# Altium schematic sheet MCU_EW.SchDoc(MCU_EW)
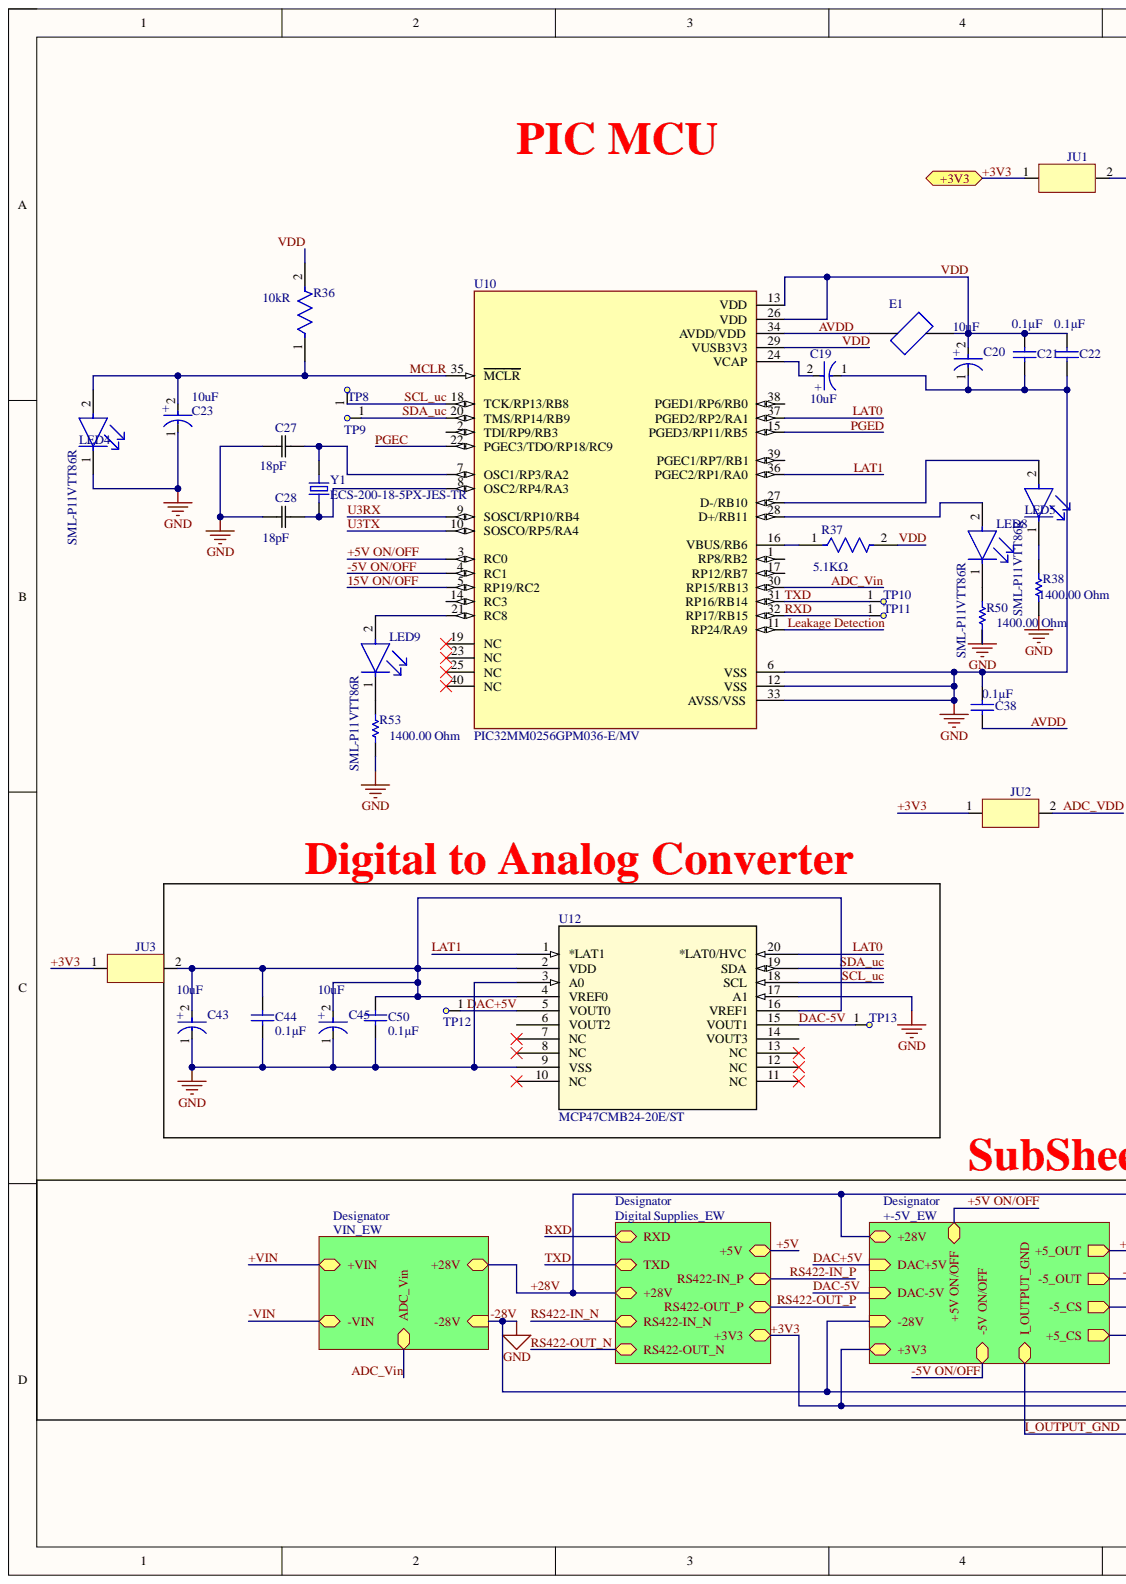
[diagram p0: MCU_EW.SchDoc, left]
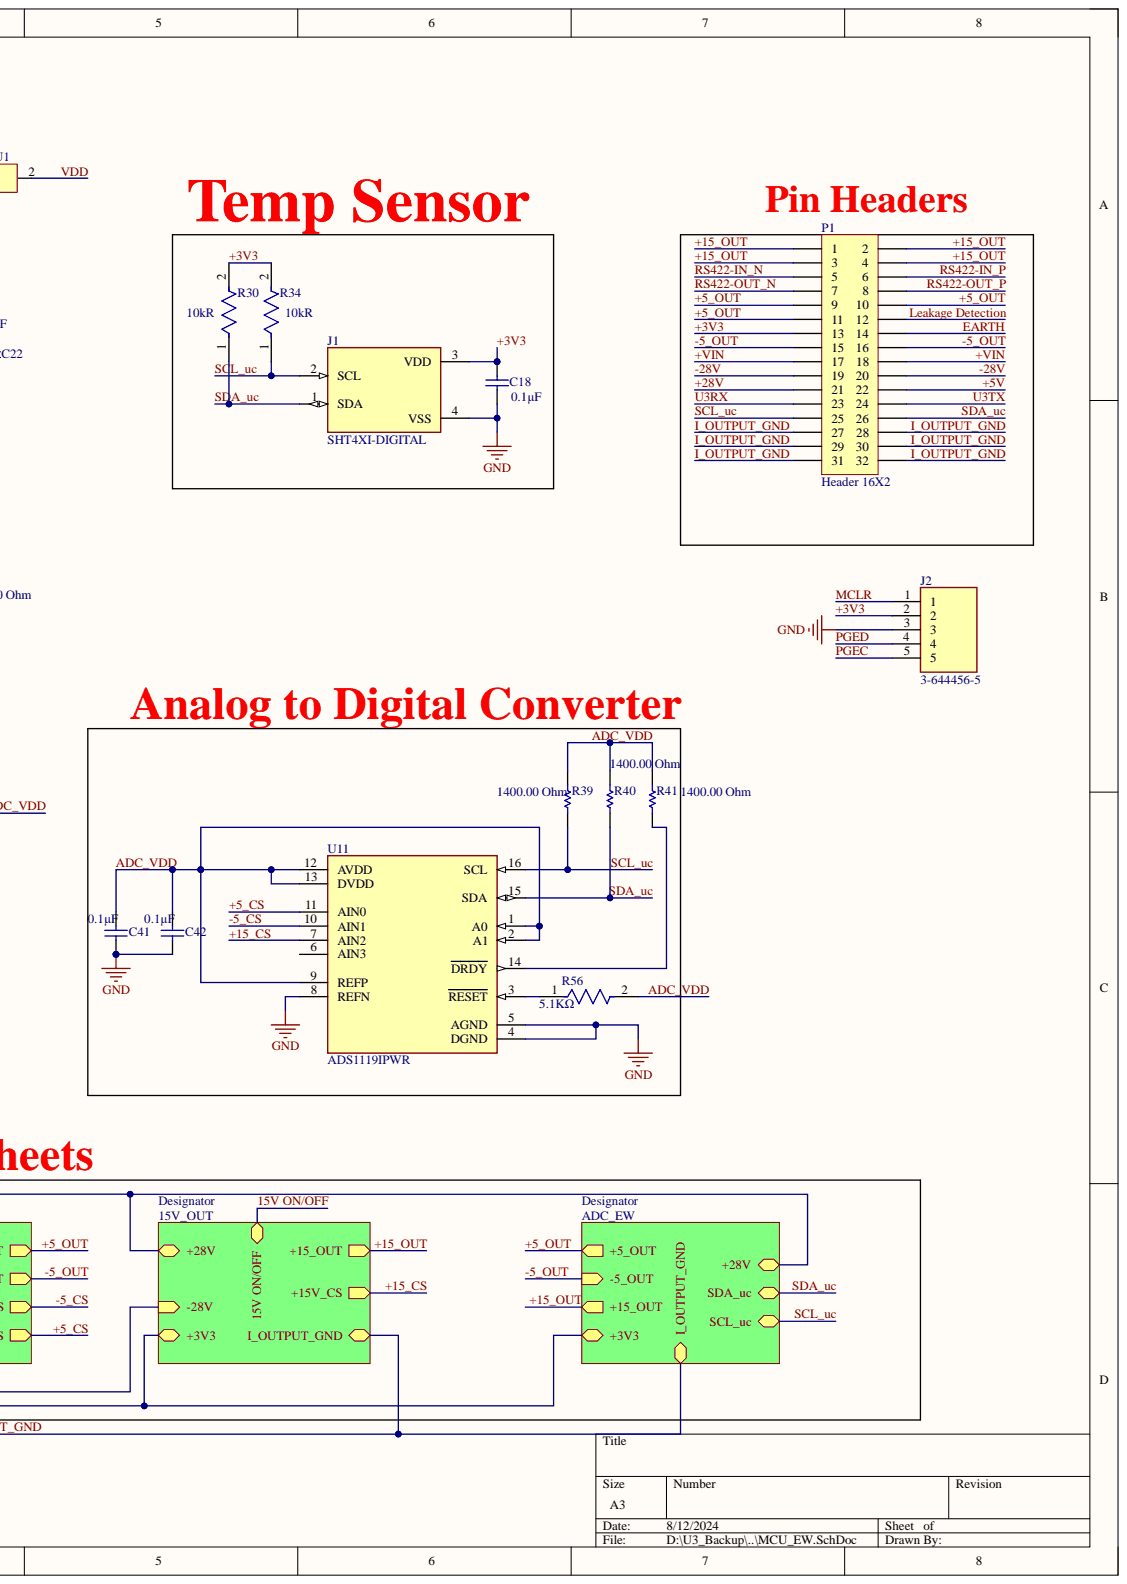
[diagram p1: MCU_EW.SchDoc, right]

## Parts
C18  Capacitance: 0.1µF  [KEMT-1206-2_L]  (hidden comment: C1206C104K5RACTU)
C19  Capacitance: 10uF  [FP-RT1206-IPC_B]  (hidden comment: TAJA106K016RNJ)
C20  TAJA106K016RNJ  [FP-RT1206-IPC_B]  (value not drawn)
C21  Capacitance: 0.1µF  [KEMT-1206-2_L]  (hidden comment: C1206C104K5RACTU)
C22  Capacitance: 0.1µF  [KEMT-1206-2_L]  (hidden comment: C1206C104K5RACTU)
C23  Capacitance: 10uF  [FP-RT1206-IPC_B]  (hidden comment: TAJA106K016RNJ)
C27  value 18pF  [CAPC340180_88N_KEM-L]  (hidden comment: CML1206C0G180JT50V)
C28  value 18pF  [CAPC340180_88N_KEM-L]  (hidden comment: CML1206C0G180JT50V)
C38  Capacitance: 0.1µF  [KEMT-1206-2_L]  (hidden comment: C1206C104K5RACTU)
C41  Capacitance: 0.1µF  [KEMT-1206-2_L]  (hidden comment: C1206C104K5RACTU)
C42  Capacitance: 0.1µF  [KEMT-1206-2_L]  (hidden comment: C1206C104K5RACTU)
C43  TAJA106K016RNJ  [FP-TAJA-MFG]  (value not drawn)
C44  Capacitance: 0.1µF  [KEMT-1206-2_L]  (hidden comment: C1206C104K5RACTU)
C45  TAJA106K016RNJ  [FP-TAJA-MFG]  (value not drawn)
C50  Capacitance: 0.1µF  [KEMT-1206-2_L]  (hidden comment: C1206C104K5RACTU)
E1  MI1812K121R-10  [FB_MI1812K121R-10]  (value not drawn)
J1  SHT4XI-DIGITAL  [SHT4XI-DIGITAL]
J2  3-644456-5  [61300511121]
JU1  (no value)  [Jumper]
JU2  (no value)  [Jumper]
JU3  (no value)  [Jumper]
LED4  SML-P11VTT86R  [FP-SML-P1-MFG]
LED5  SML-P11VTT86R  [FP-SML-P1-MFG]
LED8  SML-P11VTT86R  [FP-SML-P1-MFG]
LED9  SML-P11VTT86R  [FP-SML-P1-MFG]
P1  Header 16X2  [HDR2X16]
R30  Resistance: 10kR  [FP-RMCF1206-MFG]  (hidden comment: RMCF1206FT10K0)
R34  Resistance: 10kR  [FP-RMCF1206-MFG]  (hidden comment: RMCF1206FT10K0)
R36  Resistance: 10kR  [FP-RMCF1206-MFG]  (hidden comment: RMCF1206FT10K0)
R37  value 5.1KΩ  Resistance: 5.1KΩ  [FP-RT1206-MFG]  (hidden comment: RT1206DRD075K1L)
R38  value 1400.00 Ohm  [RESC1206(3216)_L]  (hidden comment: 1K4 1% 1206(3216))
R39  value 1400.00 Ohm  [RESC1206(3216)_L]  (hidden comment: 1K4 1% 1206(3216))
R40  value 1400.00 Ohm  [RESC1206(3216)_L]  (hidden comment: 1K4 1% 1206(3216))
R41  value 1400.00 Ohm  [RESC1206(3216)_L]  (hidden comment: 1K4 1% 1206(3216))
R50  value 1400.00 Ohm  [RESC1206(3216)_L]  (hidden comment: 1K4 1% 1206(3216))
R53  value 1400.00 Ohm  [RESC1206(3216)_L]  (hidden comment: 1K4 1% 1206(3216))
R56  value 5.1KΩ  Resistance: 5.1KΩ  [1206(3216)]  (hidden comment: RT1206DRD075K1L)
TP8  Test Point  [Test Point]  (value not drawn)
TP9  Test Point  [Test Point]  (value not drawn)
TP10  Test Point  [Test Point]  (value not drawn)
TP11  Test Point  [Test Point]  (value not drawn)
TP12  Test Point  [Test Point]  (value not drawn)
TP13  Test Point  [Test Point]  (value not drawn)
U10  PIC32MM0256GPM036-E/MV  [QFN40P500X500X55-41N]  (value not drawn)
U11  ADS1119IPWR  [FP-PW0016A-IPC_A]
U12  MCP47CMB24-20E/ST  [TSSOP20_ST_MCH]
Y1  ECS-200-18-5PX-JES-TR  [XTAL_ECS-200-18-5PX-JES-TR]

## Ports
+3V3

## Nets
15V ON/OFF: P1.1 P1.2 P1.3 P1.4 U10.5(RP19/RC2) U11.7(AIN2)  [also labelled +15_CS, +15_OUT]
+3V3: C18.2 J1.3(VDD) J2.2 JU1.1 JU2.1 JU3.1 P1.13 R30.2 R34.2 ; port +3V3
+5_CS: U11.11(AIN0)
+5_OUT: P1.9 P1.10 P1.11
+5V: P1.22
+5V ON/OFF: U10.3(RC0)
+28V: P1.21
+VIN: P1.17 P1.18
-5_CS: U11.10(AIN1)
-5_OUT: P1.15 P1.16
-5V ON/OFF: U10.4(RC1)
-28V: C18.1 C19.1 C20.1 C21.1 C22.1 C23.1 C27.1 C28.1 C38.2 C41.1 C42.1 C43.1 C44.1 C45.1 C50.1 J1.4(VSS) J2.3 LED4.1 P1.19 P1.20 R38.1 R50.1 R53.1 U10.6(VSS) U10.12(VSS) U10.33(AVSS/VSS) U11.4(DGND) U11.5(AGND) U11.8(REFN) U12.3(A0) U12.9(VSS) U12.17(A1)
ADC_VDD: C41.2 C42.2 JU2.2 R39.2 R40.2 R41.2 R56.2 U11.1(A0) U11.2(A1) U11.9(REFP) U11.12(AVDD) U11.13(DVDD)
ADC_Vin: U10.30(RP15/RB13)
AVDD: C38.1 E1.1 U10.34(AVDD/VDD)
DAC+5V: TP12.1 U12.5(VOUT0)
DAC-5V: TP13.1 U12.15(VOUT1)
EARTH: P1.14
I_OUTPUT_GND: P1.27 P1.28 P1.29 P1.30 P1.31 P1.32
LAT0: U10.37(PGED2/RP2/RA1) U12.20(*LAT0/HVC)
LAT1: U10.36(PGEC2/RP1/RA0) U12.1(*LAT1)
Leakage Detection: P1.12 U10.11(RP24/RA9)
MCLR: C23.2 J2.1 LED4.2 R36.1 U10.35(MCLR)
PGEC: J2.5 U10.22(PGEC3/TDO/RP18/RC9)
PGED: J2.4 U10.15(PGED3/RP11/RB5)
RS422-IN_N: P1.5
RS422-IN_P: P1.6
RS422-OUT_N: P1.7
RS422-OUT_P: P1.8
RXD: TP11.1 U10.32(RP17/RB15)
SCL_uc: J1.2(SCL) P1.25 R34.1 R39.1 TP8.1 U10.18(TCK/RP13/RB8) U11.16(SCL) U12.18(SCL)
SDA_uc: J1.1(SDA) P1.26 R30.1 R40.1 TP9.1 U10.20(TMS/RP14/RB9) U11.15(SDA) U12.19(SDA)
TXD: TP10.1 U10.31(RP16/RB14)
U3RX: P1.23 U10.9(SOSCI/RP10/RB4)
U3TX: P1.24 U10.10(SOSCO/RP5/RA4)
VDD: C20.2 C21.2 C22.2 E1.2 JU1.2 R36.2 R37.2 U10.13(VDD) U10.26(VDD) U10.29(VUSB3V3)
NetC19_2: C19.2 U10.24(VCAP)
NetC27_2: C27.2 U10.7(OSC1/RP3/RA2) Y1.2
NetC28_2: C28.2 U10.8(OSC2/RP4/RA3) Y1.1
NetC43_2: C43.2 C44.2 C45.2 C50.2 JU3.2 U12.2(VDD) U12.4(VREF0) U12.16(VREF1)
NetLED5_1: LED5.1 R38.2
NetLED5_2: LED5.2 U10.27(D-/RB10)
NetLED8_1: LED8.1 R50.2
NetLED8_2: LED8.2 U10.28(D+/RB11)
NetLED9_1: LED9.1 R53.2
NetLED9_2: LED9.2 U10.21(RC8)
NetR37_1: R37.1 U10.16(VBUS/RB6)
NetR41_1: R41.1 U11.14(DRDY)
NetR56_1: R56.1 U11.3(RESET)
NetU10_1: U10.1(RP8/RB2)
NetU10_2: U10.2(TDI/RP9/RB3)
NetU10_14: U10.14(RC3)
NetU10_17: U10.17(RP12/RB7)
NetU10_19: U10.19(NC)
NetU10_23: U10.23(NC)
NetU10_25: U10.25(NC)
NetU10_38: U10.38(PGED1/RP6/RB0)
NetU10_39: U10.39(PGEC1/RP7/RB1)
NetU10_40: U10.40(NC)
NetU11_6: U11.6(AIN3)
NetU12_6: U12.6(VOUT2)
NetU12_7: U12.7(NC)
NetU12_8: U12.8(NC)
NetU12_10: U12.10(NC)
NetU12_11: U12.11(NC)
NetU12_12: U12.12(NC)
NetU12_13: U12.13(NC)
NetU12_14: U12.14(VOUT3)
-VIN:

## Library data of the parts
C18 C41 C42 C44 C50 = 0.1uF: CAP, Ceramic, 0.1 uF, +/- 10%, 50 V, -55 to 125 degC, 1206 (3216 Metric), RoHS, Tape and Reel; Manufacturer: Kemet; Capacitor Case Style: 1206[3216Metric]; Dielectric Characteristic: X7R; Product Range: KEMET-CSeries; SVHC: ToBeAdvised; Voltage Rating: 50V; Capacitance Tolerance: ±10%; Component Kind: Standard; Datasheet URL: https://datasheet.ciiva.com/6125/1725438-6125494.pdf; Datasheet Version: Jul-13; Library Name: Lib.SchLib; Manufacturer URL: http://www.kemet.com/; Operating Temperature Max: 125degC; Operating Temperature Min: -55degC; Package Description: 2-Leads SMD, Body 3.2 x 1.6 mm; Package Reference: 1206-2; Packaging: Tape&Reel
C19 = CMP-1661-00004-2: 10uF 16V  ±10% 3Ω 1206  SMD Tantalum Capacitor; Dissipation Factor: 8%; ESR (Equivalent Series Resistance): 3R; Leakage Current: 1.6uA; Polarity: Polar; Case Code (Imperial): 1206; Case Code (Metric): 3216; Case/Package: 1206; Component Kind: Standard; Depth: 1.6mm; Dielectric Material: Tantalum; Features: General Purpose; Height: 1.6mm; Height - Seated (Max): 0.071inch; Lead Free: Lead Free; Lead Pitch: 1.1mm; Length: 3.2mm; Library Name: PIC library.SchLib; Max Operating Temperature: 125°C; Min Operating Temperature: -55°C; Mount: Surface Mount; Number of Pins: 2; Package Quantity: 1; Packaging: Tape and Reel; Radiation Hardening: No; REACH SVHC: No SVHC; Termination: SMD/SMT; Tolerance: 10%; Voltage: 16V; Voltage Rating: 16V; Voltage Rating (DC): 16V; Width: 1.6mm
C20 C23 = CMP-1661-00004-2_1: 10uF 16V  ±10% 3Ω 1206  SMD Tantalum Capacitor; Dissipation Factor: 8%; ESR (Equivalent Series Resistance): 3R; Leakage Current: 1.6uA; Polarity: Polar; Case Code (Imperial): 1206; Case Code (Metric): 3216; Case/Package: 1206; Component Kind: Standard; Depth: 1.6mm; Dielectric Material: Tantalum; Features: General Purpose; Height: 1.6mm; Height - Seated (Max): 0.071inch; Lead Free: Lead Free; Lead Pitch: 1.1mm; Length: 3.2mm; Library Name: PIC library.SchLib; Max Operating Temperature: 125°C; Min Operating Temperature: -55°C; Mount: Surface Mount; Number of Pins: 2; Package Quantity: 1; Packaging: Tape and Reel; Radiation Hardening: No; REACH SVHC: No SVHC; Termination: SMD/SMT; Tolerance: 10%; Voltage: 16V; Voltage Rating: 16V; Voltage Rating (DC): 16V; Width: 1.6mm
  C20: Capacitance: 10uF
C21 C22 C38 = CMP-1037-04202-2: CAP, Ceramic, 0.1 uF, +/- 10%, 50 V, -55 to 125 degC, 1206 (3216 Metric), RoHS, Tape and Reel; Manufacturer: Kemet; Capacitor Case Style: 1206[3216Metric]; Dielectric Characteristic: X7R; Product Range: KEMET-CSeries; SVHC: ToBeAdvised; Voltage Rating: 50V; Capacitance Tolerance: ±10%; Component Kind: Standard; Datasheet URL: https://datasheet.ciiva.com/6125/1725438-6125494.pdf; Datasheet Version: Jul-13; Library Name: PIC library.SchLib; Manufacturer URL: http://www.kemet.com/; Operating Temperature Max: 125degC; Operating Temperature Min: -55degC; Package Description: 2-Leads SMD, Body 3.2 x 1.6 mm; Package Reference: 1206-2; Packaging: Tape&Reel
C27 C28 = C1206C180K8HAC7800: 18pF Cap; Mfr_Name: Kemet; Component Kind: Standard; Library Name: Lib.SchLib
C43 C45 = 10uF: 10uF 16V  ±10% 3Ω 1206  SMD Tantalum Capacitor; Dissipation Factor: 8%; ESR (Equivalent Series Resistance): 3R; Leakage Current: 1.6uA; Polarity: Polar; Capacitance: 10uF; Case Code (Imperial): 1206; Case Code (Metric): 3216; Case/Package: 1206; Component Kind: Standard; Depth: 1.6mm; Dielectric Material: Tantalum; Features: General Purpose; Height: 1.6mm; Height - Seated (Max): 0.071inch; Lead Free: Lead Free; Lead Pitch: 1.1mm; Length: 3.2mm; Library Name: Lib.SchLib; Max Operating Temperature: 125°C; Min Operating Temperature: -55°C; Mount: Surface Mount; Number of Pins: 2; Package Quantity: 1; Packaging: Tape and Reel; Radiation Hardening: No; REACH SVHC: No SVHC; Termination: SMD/SMT; Tolerance: 10%; Voltage: 16V; Voltage Rating: 16V; Voltage Rating (DC): 16V; Width: 1.6mm
E1 = MI1812K121R-10: 120 Ohms @ 100MHz 1 Power Line Ferrite Bead 1812 (4532 Metric) 1.5A 55mOhm; MF: Laird; Availability: In Stock; Check_prices: https://www.snapeda.com/parts/MI1812K121R-10/Laird/view-part/337601/?ref=eda; Component Kind: Standard; Library Name: Lib.SchLib; MP: MI1812K121R-10; Package: 1812 Laird; Price: None; SnapEDA_Link: https://www.snapeda.com/parts/MI1812K121R-10/Laird/view-part/337601/?ref=snap
J1 = SHT4XI-DIGITAL: Industrial Grade Digital Relative Humidity Temperature Sensor, Â±2% / max 2.5% RH, Â±0.2 Â°C; MF: Sensirion; MP: SHT41I-AD1B-R3; Availability: In Stock; Check_prices: https://www.snapeda.com/parts/SHT41I-AD1B-R3/Sensirion/view-part/?ref=eda; Component Kind: Standard; Package: DFN-4 Sensirion; Price: None; Purchase-URL: https://pricing.snapeda.com/search?q=SHT41I-AD1B-R3&ref=eda; SnapEDA_Link: https://www.snapeda.com/parts/SHT41I-AD1B-R3/Sensirion/view-part/?ref=snap; Library Name: RU_SchLib.SchLib
J2 = 3-644456-5: MTA-100 5 Position Through-Hole Single Row Straight Wire to Board Header; Centerline_Pitch: 2.54 mm[.1 in]; MF: TE Connectivity; Number_of_Positions: 5; Product_Type: Connector; Component Kind: Standard; Digi-Key_Part_Number: A31115-ND; Digi-Key_Purchase_URL: https://www.digikey.com/product-detail/en/te-connectivity-amp-connectors/3-644456-5/A31115-ND/698347?utm_source=snapeda&utm_medium=aggregator&utm_campaign=symbol; Library Name: PIC library.SchLib; MP: 3-644456-5; Package: None; SnapEDA_Link: https://www.snapeda.com/parts/3-644456-5/TE%20Connectivity%20AMP%20Connectors/view-part/2778068/?ref=snap; TE_purchase_URL: https://www.te.com/usa-en/product-3-644456-5.html?te_bu=Cor&te_type=disp&te_campaign=seda_glo_cor-seda-global-disp-prtnr-fy19-seda-model-bom-cta_sma-317_1&elqCampaignId=32493
JU1-JU3 = Jumper: Component Kind: Standard; Description: Jumper
LED4 LED5 LED8 LED9 = SML-P11VTT86R: LED RED CLEAR 0402 (1006) SMD; Manufacturer: Rohm; Forward Current: 1mA; Forward Voltage: 1.8V; Led Orientation: Top View; Luminous Intensity: 4mcd; Power Dissipation: 50mW; Reverse Voltage: 5V; Wavelength: 626nm; Automotive: No; Category: Diode; Colour: Red; Component Kind: Standard; ComponentLink2Description: Datasheet; ComponentLink2URL: https://www.rohm.com/datasheet/SML-P11VT(R)/sml-p11-e; Device Class L1: Optoelectronics; Device Class L2: LEDs; Device Class L3: unset; Digikey Description: LED RED CLEAR 1006 SMD; Height: 0.25mm; Lead Free: Yes; Library Name: PIC library.SchLib; Manufacturer Part Number: SML-P11VTT86R; Mouser Description: SML-P11 Series Red 0402 Clear 4 mcd 1.8 V Surface Mount PICOLED? LED; Octopart: https://octopart.com/sml-p11vtt86r-rohm-34112314; Package: SML-P1; RoHS: TRUE; Temperature: -40°C to +85°C; Temperature Range High: +85°C; Temperature Range Low: -40°C
  LED8 LED9: Simulation: L_Inductor_Default
P1 = Header 16X2: Header, 16-Pin, Dual row; Component Kind: Standard; LatestRevisionDate: 17-Jul-2002; LatestRevisionNote: Re-released for DXP Platform.; Library Name: Miscellaneous Connectors.IntLib; Signal Integrity: Connector; Publisher: Altium Limited
R30 R34 R36 = CMP-26527-000151-1: 10kΩ ±1% 0.25W 1206 Thick Film Chip Resistor AEC-Q200 compliant; Max Power Dissipation: 250mW; Temperature Coefficient: 100ppm/°C; Case Code (Imperial): 1206; Case Code (Metric): 3216; Case/Package: 1206; Component Kind: Standard; Construction: Rectangular; Contact Plating: Tin; Depth: 1.6mm; Features: Automotive AEC-Q200; Height: 0.028inch; Lead Free: Lead Free; Length: 3.2mm; Library Name: Lib.SchLib; Max Operating Temperature: 155°C; Military Standard: Not; Min Operating Temperature: -55°C; Mount: Surface Mount; Number of Terminations: 2; Packaging: Tape and Reel; Power Rating: 250mW; Radiation Hardening: No; Resistor Type: Thick Film; RoHS Compliant: Yes; Series: RMCF; Size Code: 1206; Tolerance: 1%; Width: 0.063inch
R37 R56 = 5.1K Ohm: RES SMD 5.1K OHM 0.5% 1/4W 1206; Manufacturer: Yageo; Power Rating: 0.25W; Temperature Coefficient: 25 ppm/°C; Tolerance: 0.0001; Voltage Rating: 200V; Automotive: No; Category: Res; Component Kind: Standard; ComponentLink2Description: Datasheet; ComponentLink2URL: http://www.yageo.com/documents/recent/PYu-RT_1-to-0.01_RoHS_L_9.pdf; Composition: Thin Film; Device Class L1: Passive Components; Device Class L2: Resistors; Device Class L3: Chip SMD Resistors; Digikey Description: RES SMD 5.1K OHM 0.5% 1/4W 1206; Footprint Url: http://www.yageo.com/exep/pages/download/literatures/PYu-R_Mount_10.pdf; Height: 0.65mm; Ipc Land Pattern Name: RESC310160X55; Lead Free: Yes; Library Name: Lib.SchLib; Manufacturer Part Number: RT1206DRD075K1L; Octopart: https://octopart.com/rt1206drd075k1l-yageo-1440623; Package: RT1206; RoHS: TRUE; Series: RT; Temperature: -55°C to +155°C; Temperature Range High: +155°C; Temperature Range Low: -55°C
R38 R39 R41 = 1.4K Ohm: 1K4 0.25W 1% 1206 (3216 Metric)  SMD; Case-EIA: 1206; Case-Metric: 3216; Component Kind: Standard; Library Name: Lib.SchLib; Power: 0.25 W; Technology: SMT; Tolerance: 1 %
R40 R50 R53 = 1.4K Ohm: 1K4 0.25W 1% 1206 (3216 Metric)  SMD; Case-EIA: 1206; Case-Metric: 3216; Value: 1400.00 Ohm; Component Kind: Standard; Library Name: Lib.SchLib; Power: 0.25 W; Technology: SMT; Tolerance: 1 %
  R50 R53: Simulation: R_Resistor_Default
U10 = PIC32MM0256GPM036-E/MV: MIPS32Â® microAptivâ„¢ PICÂ® 32MM Microcontroller IC 32-Bit 25MHz 256KB (256K x 8) FLASH 40-UQFN (5x5); MF: Microchip Technology; Availability: Warning; Check_prices: https://www.snapeda.com/parts/PIC32MM0256GPM036-E/MV/Microchip/view-part/5853699/?ref=eda; Component Kind: Standard; Library Name: Schlib1.SchLib; MP: PIC32MM0256GPM036-E/MV; Package: None; Price: None; Purchase-URL: https://pricing.snapeda.com/search/part/PIC32MM0256GPM036-E/MV/?ref=eda; SnapEDA_Link: https://www.snapeda.com/parts/PIC32MM0256GPM036-E/MV/Microchip/view-part/5853699/?ref=snap
U11 = CMP-04860-000050-1: IC ADC 16BIT SIGMA-DELTA 16TSSOP; Interface: I2C; Number of A/D Converters: 1; Number of Bits: 16; Number of Inputs: 4; Sampling Rate: 1000S/s; Case/Package: TSSOP; Component Kind: Standard; Library Name: Schlib1.SchLib; Max Operating Temperature: 125°C; Min Operating Temperature: -40°C; Packaging: Tape and Reel; RoHS Compliant: Yes
U12 = MCP47CMB24-20E/ST: No Description Available; Mfr_Name: Microchip; Component Kind: Standard; Library Name: PIC library.SchLib; RefDes: RefDes; Type: Type
Y1 = ECS-200-18-5PX-JES-TR: 20MHz Â±20ppm Crystal 18pF 30 Ohms HC-49/US; MF: ECS Inc.; Availability: Good; Check_prices: https://www.snapeda.com/parts/ECS-200-18-5PX-JES-TR/ECS%20Inc./view-part/4246648/?ref=eda; Component Kind: Standard; MP: ECS-200-18-5PX-JES-TR; Package: HC49/US ECS International; Price: None; Purchase-URL: https://pricing.snapeda.com/search/part/ECS-200-18-5PX-JES-TR/?ref=eda; SnapEDA_Link: https://www.snapeda.com/parts/ECS-200-18-5PX-JES-TR/ECS%20Inc./view-part/4246648/?ref=snap; Library Name: RU_SchLib.SchLib
TP8-TP13 = Test Point: Component Kind: Standard; Description: Test Point; Library Name: Lib.SchLib
